# Altium schematic sheet drv8886_breakout.SchDoc
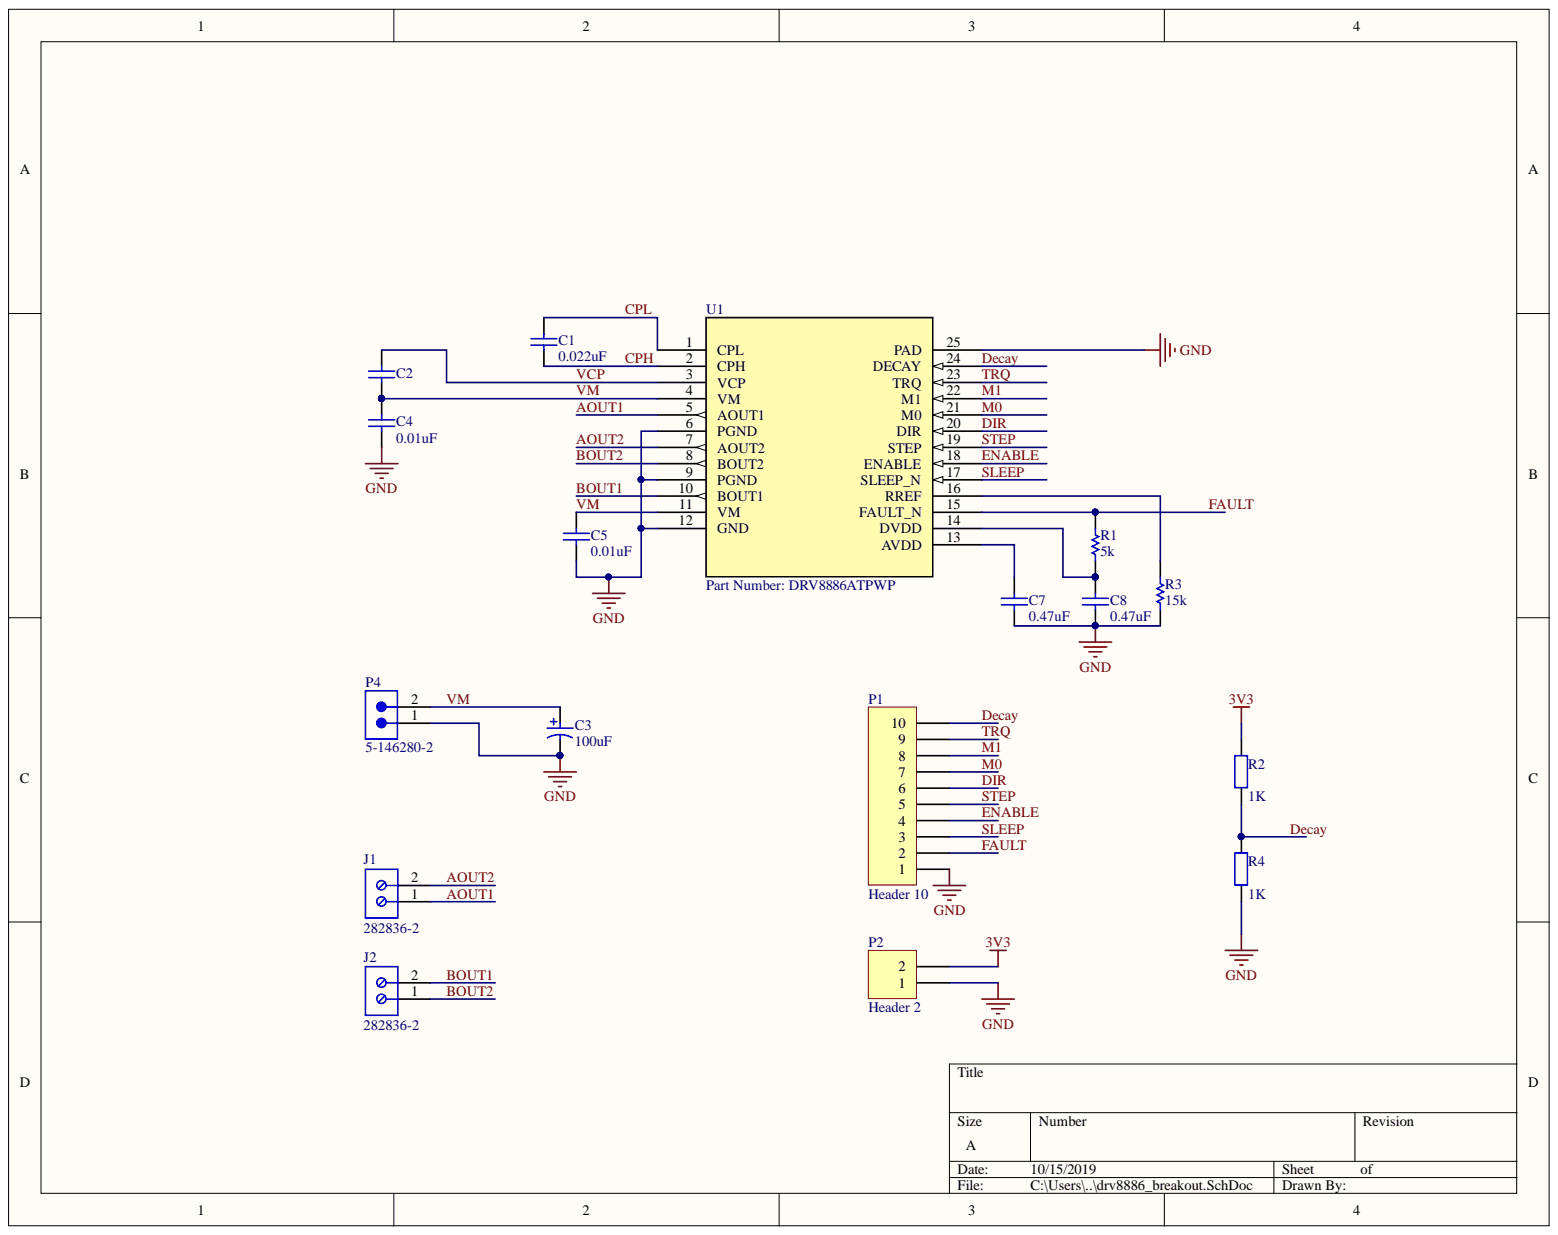
[diagram p0: drv8886_breakout.SchDoc]

## Parts
C1  0.022uF  [CAPC1608X87X35ML15T15]
C2  0.22uF  [TDK-C1608-2_A_M]  (value not drawn)
C3  100uF  [PNSC-FK-V-F_V]
C4  0.01uF  [CAPC1608X87X35LL15T15]
C5  0.01uF  [CAPC1608X87X35LL15T15]
C7  0.47uF  [CAPC1608X90X35ML15T15]
C8  0.47uF  [CAPC1608X90X35ML15T15]
J1  282836-2  [TECO-282836-2_V]
J2  282836-2  [TECO-282836-2_V]
P1  Header 10  [HDR1X10]
P2  Header 2  [HDR1X2]
P4  5-146280-2  [TECO-5-146280-2_V]
R1  5k  [RESC1608X55X25ML10T15]
R2  value 1K  [AXIAL-0.4]  (hidden comment: ?)
R3  15k  [RESC1608X55X25ML10T15]
R4  value 1K  [AXIAL-0.4]  (hidden comment: Res2)
U1  Part Number: DRV8886ATPWP  [PWP0024B_N]

## Nets
3V3: P2.2 R2.2
GND: C3.2 C4.2 C5.2 C7.1 C8.1 P1.1 P2.1 P4.1 R3.1 R4.1 U1.6(PGND) U1.9(PGND) U1.12(GND) U1.25(PAD)
~{FAULT}: P1.2 R1.2 U1.15(FAULT_N)
~{SLEEP}: P1.3 U1.17(SLEEP_N)
AOUT1: J1.1 U1.5(AOUT1)
AOUT2: J1.2 U1.7(AOUT2)
BOUT1: J2.2 U1.10(BOUT1)
BOUT2: J2.1 U1.8(BOUT2)
CPH: C1.1 U1.2(CPH)
CPL: C1.2 U1.1(CPL)
Decay: P1.10 R2.1 R4.2 U1.24(DECAY)
DIR: P1.6 U1.20(DIR)
ENABLE: P1.4 U1.18(ENABLE)
M0: P1.7 U1.21(M0)
M1: P1.8 U1.22(M1)
STEP: P1.5 U1.19(STEP)
TRQ: P1.9 U1.23(TRQ)
VCP: C2.2 U1.3(VCP)
VM: C2.1 C3.1 C4.1 C5.1 P4.2 U1.4(VM) U1.11(VM)
NetC7_2: C7.2 U1.13(AVDD)
NetC8_2: C8.2 R1.1 U1.14(DVDD)
NetR3_2: R3.2 U1.16(RREF)

## Library data of the parts
C1 = CMP-2006-03139-1: Manufacturer: Kemet; Part Number: C0603C223K4RACTU; Component Kind: Standard; Library Name: Altium Content Vault
C2 = CMP-2000-05394-1: Cap Ceramic, 0.22 uF, +/- 10%, -55 to 125 degC, 2-Pin SMD (0603), RoHS, Tape and Reel; Manufacturer: TDK; PCN Part Number: MLCCPartNumberChange30/Nov/2012; Capacitance: 0.22µF; Design Resources: 20WNon-IsolatedBuckLEDDriverUsingPLLNK460KG; Kits: 445-9014-KIT-ND-KITMLCC100PF-22UF060310-50V445-9003-KIT-ND-KITCAPCERHICAPMLCCSMD445-173086-KIT-ND-C0402-2220X7R6.3-50V1,000PF-; Online Catalog: CSeries,GeneralPurpose; Other Names: 445-7408-1; Product Training Modules: CSeriesGeneralApplicationsSEAT,CCV,andTVCLDesignToolsGuidetoReplacinganElectrolyticCapacitorwithanMLCC; Temperature Coefficient: X7R; Voltage - Rated: 50V; Applications: GeneralPurpose; Category: Capacitors; Component Kind: Standard; Datasheet URL: https://datasheet.ciiva.com/24218/c1608x7r1h224k080ab-264841-24218813.pdf; Datasheet Version: Sep-13; Family: CeramicCapacitors; Features: -; Height - Seated (Max): -; Lead Spacing: -; Lead Style: -; Library Name: Altium Content Vault; Manufacturer URL: http://www.tdk.com/; Mounting Type: SurfaceMount,MLCC; Operating Temperature: -55degC~125degC; Package / Case: 0603(1608Metric); Package Description: 2-Pin Chip Capacitor, Body 1.7 x 0.9 mm; Package Reference: 603; Packaging: CutTape(CT); Ratings: -; RoHS Information: RoHSCertificate-MLCC; Series: C; Size / Dimension: 0.063"Lx0.031"W(1.60mmx0.80mm); Standard Package: 1; Thickness (Max): 0.035"(0.90mm); Tolerance: ±10%
C3 = CMP-2000-06208-1: Aluminum Electrolytic Capacitor, 100 uF, +/- 20%, 50 V, 2-Pin SMD (Size Code F), -55 to 105 degC, RoHS, Tape and Reel; Manufacturer: Panasonic; Capacitance: 100µF; Impedance: 0.34O; Lifetime@Temperature: 2000Hrs@105°C; Rated Voltage: 50V; Ripple Current: 350mA; Type: Can; Case Size/Dimension: Ø8x10.2mm; Component Kind: Standard; Datasheet URL: http://industrial.panasonic.com/cdbs/www-data/pdf/RDE0000/ABA0000C1181.pdf; Datasheet Version: 03/2016; Library Name: Altium Content Vault; Manufacturer URL: http://www.panasonic.com/; Mounting Technology: SurfaceMount; Operating Temp-Max: 105°C; Operating Temp Range: -55to+105°C; Package Description: 2-Pin Electrolytic Aluminum Capacitor, Body 8.3 x 8.3 mm; Package Reference: FK-V-F; Package URL: http://industrial.panasonic.com/cdbs/www-data/pdf/RDE0000/DME0000COL48.pdf; Series: FK
C4 C5 = CMP-2006-03299-1: Manufacturer: Kemet; Part Number: C0603X103K5RACTU; Component Kind: Standard; Library Name: Altium Content Vault
C7 C8 = CMP-2006-04187-1: Manufacturer: AVX Interconnect / Elco; Part Number: 06033C474KAT2A; Component Kind: Standard; Library Name: Altium Content Vault
J1 J2 = CMP-1684-00017-1: Female Header, Pitch 5 mm, 1 x 2 Position, Height 10 mm, Tail Length 3.5 mm, Rohs, Bulk; Manufacturer: TE Connectivity; 3D Model: 282836-2.pdf; Clamp Material - Plating: Brass-NickelPlated; Current: 13.5A; Dynamic Catalog: BuchananSeries; Featured Product: EurostyleTerminalBlockConnectors; Mating Orientation: HorizontalwithBoard; Number of Levels: 1; Other Names: 5ULV-02B  A98076; Pitch: 0.197"(5.00mm); Positions Per Level: 2; Product Training Modules: Wire-to-BoardEurostyleTerminalBlocks; Screw Thread: M3; Torque - Screw: 0.68Nm(6Lb-In); Voltage: 300V; Wire Gauge: 16-30AWG; Wire Termination: Screw-RisingCageClamp; Category: Connectors,Interconnects; Color: Green; Component Kind: Standard; Contact Material - Plating: Brass-TinPlated; Datasheet URL: http://www.te.com.cn/commerce/DocumentDelivery/DDEController?Action=showdoc&DocId=Catalog+Section%7F1308389_EUROSTYLE_TERMINAL_BLOCKS%7F0607%7Fpdf%7FEnglish%7FENG_CS_1308389_EUROSTYLE_TERMINAL_BLOCKS_0607.pdf%7F282836-2; Family: TerminalBlocks-WiretoBoard; Features: Interlocking(Side); Housing Material: Polyamide(PA66),Nylon6/6; Library Name: Altium Content Vault; Manufacturer URL: http://www.te.com; Mounting Type: ThroughHole; Package Description: Female Header, Pitch 5 mm, 1 x 2 Position, Height 10 mm, Tail Length 3.5 mm; Package Reference: THD; Packaging: Bulk; RoHS Information: 282836-2StatementofCompliance; Screw Material - Plating: Brass-NickelPlated; Series: Buchanan; Standard Package: 300
P1 = Header 10: Header, 10-Pin; Component Kind: Standard; LatestRevisionDate: 17-Jul-2002; LatestRevisionNote: Re-released for DXP Platform.; Library Name: Miscellaneous Connectors.IntLib; Signal Integrity: Connector; Publisher: Altium Limited
P2 = Header 2: Header, 2-Pin; Component Kind: Standard; LatestRevisionDate: 17-Jul-2002; LatestRevisionNote: Re-released for DXP Platform.; Library Name: Miscellaneous Connectors.IntLib; Signal Integrity: Connector; Publisher: Altium Limited
P4 = CMP-2000-07492-1: Male Header, Pitch 2.54 mm, 1 x 2 Position, Height 8.21 mm, Tail Length 3.05 mm, -65 to 105 degC, RoHS, Bulk; Manufacturer: TE Connectivity; Approvals: CSA; Board Standoff: No(Without); Case\/Package: 100; Current Rating: 3A; High Speed Serial Data Connector: No; High Temperature Housing: Yes; Mating Post Length: 0.23inch; Max Current Rating: 5A; Number of Contacts: 2; PCB Mounting Orientation: Vertical; PCB Mount Retention: Without; PCB Thickness: 0.063inch; Pitch: 2.54mm; Colour: Black; Component Kind: Standard; Connector Type: Header; Contact Gender: Male; Contact Material: CopperAlloy; Contact Mating Length: 0.23inch; Contact Plating: Matte; Contact Protection: No(Without); Contact Shape: Square; Datasheet URL: http://www.te.com/commerce/DocumentDelivery/DDEController?Action=showdoc&DocId=Customer+Drawing%7F146280%7FH1%7Fpdf%7FEnglish%7FENG_CD_146280_H1.pdf%7F5-146280-2; Datasheet Version: Rev. H1, 08/2016; Depth: 2.34mm; Flammability Rating: UL94V-0; Gasket: No(Without); Housing Colour: Black; Housing Material: Polymer; Keyed: No; Length: 4.67mm; Library Name: Altium Content Vault; Manufacturer URL: http://www.te.com/; Mating Alignment: No(Without); Mating Connector Lock: No(Without); Max Operating Temperature: 105degC; Min Operating Temperature: -65degC; Mount: ThroughHole; Mounting Technology: ThroughHole; Number of Positions: 2; Number of Rows: 1; Orientation: Straight; Package Description: Male Header, Pitch 2.54 mm, 1 x 2 Position, Height 8.21 mm, Tail Length 3.05 mm; Package Quantity: 500; Package Reference: 5-146280-2; Packaging: Carton; Panel Mount Retention: No(Without); Radiation Hardening: No; RoHSCompliant: true; Row Spacing: 2.54mm; Selectively Loaded: No(Without); Stabilizers: No(Without); Termination: ThroughHole; Termination Post Length: 0.12inch
R1 R3 = CMP-2100-03667-1: Chip Resistor, 0 Ohm, +/- 5%, 0.1 W, -55 to 155 degC, 0603 (1608 Metric), RoHS, Tape and Reel; Manufacturer: Yageo; Power Rating: 100mW; Resistance: 0mOhm; Temperature Coefficient: 200ppm/degreesC; Voltage Rating: 50V; Case/Package: 0603; Case Code (Imperial): 0603; Case Code (Metric): 1608; Component Kind: Standard; Composition: ThickFilm; Contact Plating: Tin; Datasheet URL: http://www.yageo.com/NewPortal/yageodocoutput?fileName=/pdf/R-Chip/PYu-RC_Group_51_RoHS_L_5.pdf; Datasheet Version: Rev. 5, 10/2016; Depth: 0.8mm; Height: 0.45mm; Lead Free: LeadFree; Length: 1.6mm; Library Name: Altium Content Vault; Manufacturer URL: http://www.yageo.com/; Max Operating Temperature: 155degC; Military Standard: Not; Min Operating Temperature: -55degC; Number of Pins: 2; Package Description: 2-Pin Surface Mount Device, Body 1.6 x 0.8 mm; Package Quantity: 5000; Package Reference: 0603; Packaging: TapeandReel; Radiation Hardening: No; REACH SVHC: NoSVHC; RoHSCompliant: true; Termination: SMD/SMT; Tolerance: 5%; Voltage Rating (DC): 50V; Width: 0.8mm
R2 R4 = Res2: Resistor; Component Kind: Standard; LatestRevisionDate: 17-Jul-2002; LatestRevisionNote: Re-released for DXP Platform.; Library Name: Miscellaneous Devices.IntLib; PackageDescription: Axial Device, Thru-Hole; 2 Leads; 0.4 in Pin Spacing; PackageReference: AXIAL-0.4; Published: 8-Jun-2000; Signal Integrity: Res; Simulation: RESISTOR; Publisher: Altium Limited
U1 = DRV8886ATPWP: No Description Available; Built_By: EMA_Jessica; Vendor: Texas Instruments; Component Kind: Standard; Library Name: 2019-10-07_21-03-12.SchLib; Manufacturer_Part_Number: DRV8886ATPWP; RefDes: RefDes; Type: DEV; Value: Value; Copyright: Copyright (C) 2018 Accelerated Designs. All rights reserved
